# Altium schematic sheet ZYNQ_JTAG.SchDoc(ZYNQ_JTAG)
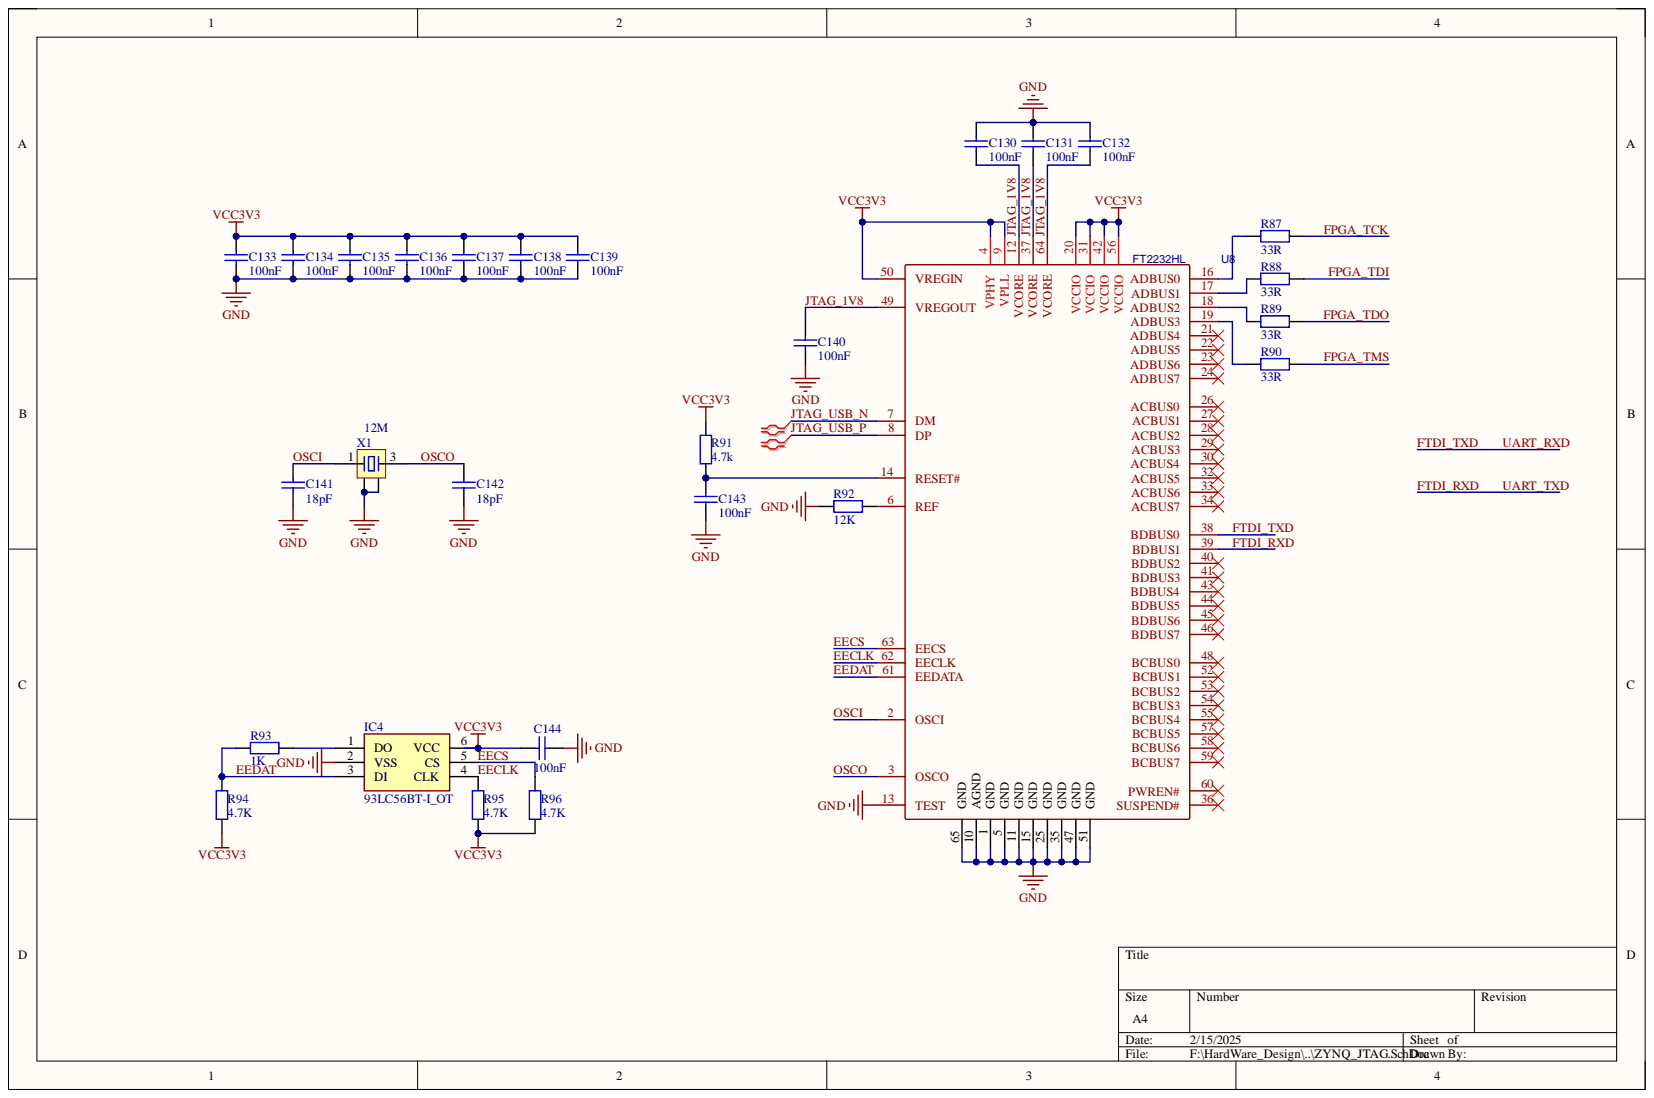
[diagram p0: ZYNQ_JTAG.SchDoc]

## Parts
C130  100nF  [C 0402_L]
C131  100nF  [C 0402_L]
C132  100nF  [C 0402_L]
C133  100nF  [C 0402_L]
C134  100nF  [C 0402_L]
C135  100nF  [C 0402_L]
C136  100nF  [C 0402_L]
C137  100nF  [C 0402_L]
C138  100nF  [C 0402_L]
C139  100nF  [C 0402_L]
C140  100nF  [C 0402_L]
C141  18pF  [C 0402_L]
C142  18pF  [C 0402_L]
C143  100nF  [C 0402_L]
C144  100nF  [C 0402_L]
IC4  93LC56BT-I_OT  [SOT95P270X145-6N]
R87  33R  [R 0402_L]
R88  33R  [R 0402_L]
R89  33R  [R 0402_L]
R90  33R  [R 0402_L]
R91  4.7k  [R 0402_L]
R92  12K  [R 0402_L]
R93  1K  [R 0402_L]
R94  4.7K  [R 0402_L]
R95  4.7K  [R 0402_L]
R96  4.7K  [R 0402_L]
U8  FT2232HL  [QFN50P900X900X100-65N-D]
X1  12M  [OSC 3225-4P]

## Nets
GND: C130.2 C131.2 C132.2 C133.1 C134.1 C135.1 C136.1 C137.1 C138.1 C139.1 C140.1 C141.1 C142.1 C143.1 C144.1 IC4.2(VSS) R92.2 U8.1(GND) U8.5(GND) U8.10(AGND) U8.11(GND) U8.13(TEST) U8.15(GND) U8.25(GND) U8.35(GND) U8.47(GND) U8.51(GND) U8.65(GND) X1.2 X1.4
VCC3V3: C133.2 C134.2 C135.2 C136.2 C137.2 C138.2 C139.2 C144.2 IC4.6(VCC) R91.2 R94.2 R95.2 R96.2 U8.4(VPHY) U8.9(VPLL) U8.20(VCCIO) U8.31(VCCIO) U8.42(VCCIO) U8.50(VREGIN) U8.56(VCCIO)
EECLK: IC4.4(CLK) R95.1 U8.62(EECLK)
EECS: IC4.5(CS) R96.1 U8.63(EECS)
EEDAT: IC4.3(DI) R93.2 R94.1 U8.61(EEDATA)
FPGA_TCK: R87.1
FPGA_TDI: R88.1
FPGA_TDO: R89.1
FPGA_TMS: R90.1
FTDI_RXD: U8.39(BDBUS1)
FTDI_TXD: U8.38(BDBUS0)
JTAG_1V8: C130.1 C131.1 C132.1 C140.2 U8.12(VCORE) U8.37(VCORE) U8.49(VREGOUT) U8.64(VCORE)
JTAG_USB_N: U8.7(DM)
JTAG_USB_P: U8.8(DP)
OSCI: C141.2 U8.2(OSCI) X1.1
OSCO: C142.2 U8.3(OSCO) X1.3
NetC143_2: C143.2 R91.1 U8.14(RESET#)
NetIC4_1: IC4.1(DO) R93.1
NetR87_2: R87.2 U8.16(ADBUS0)
NetR88_2: R88.2 U8.17(ADBUS1)
NetR89_2: R89.2 U8.18(ADBUS2)
NetR90_2: R90.2 U8.19(ADBUS3)
NetR92_1: R92.1 U8.6(REF)

Not connected: U8 21(ADBUS4) 22(ADBUS5) 23(ADBUS6) 24(ADBUS7) 26(ACBUS0) 27(ACBUS1) 28(ACBUS2) 29(ACBUS3) 30(ACBUS4) 32(ACBUS5) 33(ACBUS6) 34(ACBUS7) 36(SUSPEND#) 40(BDBUS2) 41(BDBUS3) 43(BDBUS4) 44(BDBUS5) 45(BDBUS6) 46(BDBUS7) 48(BCBUS0) 52(BCBUS1) 53(BCBUS2) 54(BCBUS3) 55(BCBUS4) 57(BCBUS5) 58(BCBUS6) 59(BCBUS7) 60(PWREN#)

## Library data of the parts
C130-C144 = Cap: 贴片电容; Component Kind: Standard; Library Name: A_Schlib.SchLib
  C130-C140 C143 C144: value: 100nF
  C141 C142: value: 18pF
IC4 = 93LC56BT-I_OT: Integrated Circuit; Manufacturer_Name: Microchip; Manufacturer_Part_Number: 93LC56BT-I/OT; Arrow Part Number: 93LC56BT-I/OT; Arrow Price/Stock: https://www.arrow.com/en/products/93lc56bt-iot/microchip-technology?region=nac; Component Kind: Standard; Datasheet Link: http://ww1.microchip.com/downloads/en/DeviceDoc/21794G.pdf; Height: 1.45mm; Library Name: SamacSys.SchLib; Mouser Part Number: 579-93LC56BT-I/OT; Mouser Price/Stock: https://www.mouser.co.uk/ProductDetail/Microchip-Technology/93LC56BT-I-OT?qs=lQv0JY93vrc7B5Rh5LPW8g%3D%3D
R87-R96 = Res: 通用; Component Kind: Standard; Library Name: A_Schlib.SchLib
  R87-R90: value: 33R
  R91: value: 4.7k
  R92: value: 12K
  R93: value: 1K
  R94-R96: value: 4.7K
U8 = FT2232HL/HQ: Manufacturer: FTDI(飞特帝亚); Manufacturer Part: FT2232HL-REEL; sourceId: X3DdNmkiu; Component Kind: Standard; JLCPCB Part Class: Extended Part; Library Name: A_Schlib.SchLib; spicePre: U; spiceSymbolName: FT2232HL; Supplier: LCSC; Supplier Part: C27882; timeStamp: 1472800160
X1 = XTAL-4P: 4脚无源晶振; 版权归属: 封装库来自淘宝卖家 ID：黄晶宝石; BOM名称: 4脚无源晶振; Component Kind: Standard; Library Name: A_Schlib.SchLib; 学习资源: https://shop463601444.taobao.com
